# Altium schematic sheet CONNECTORS.SchDoc
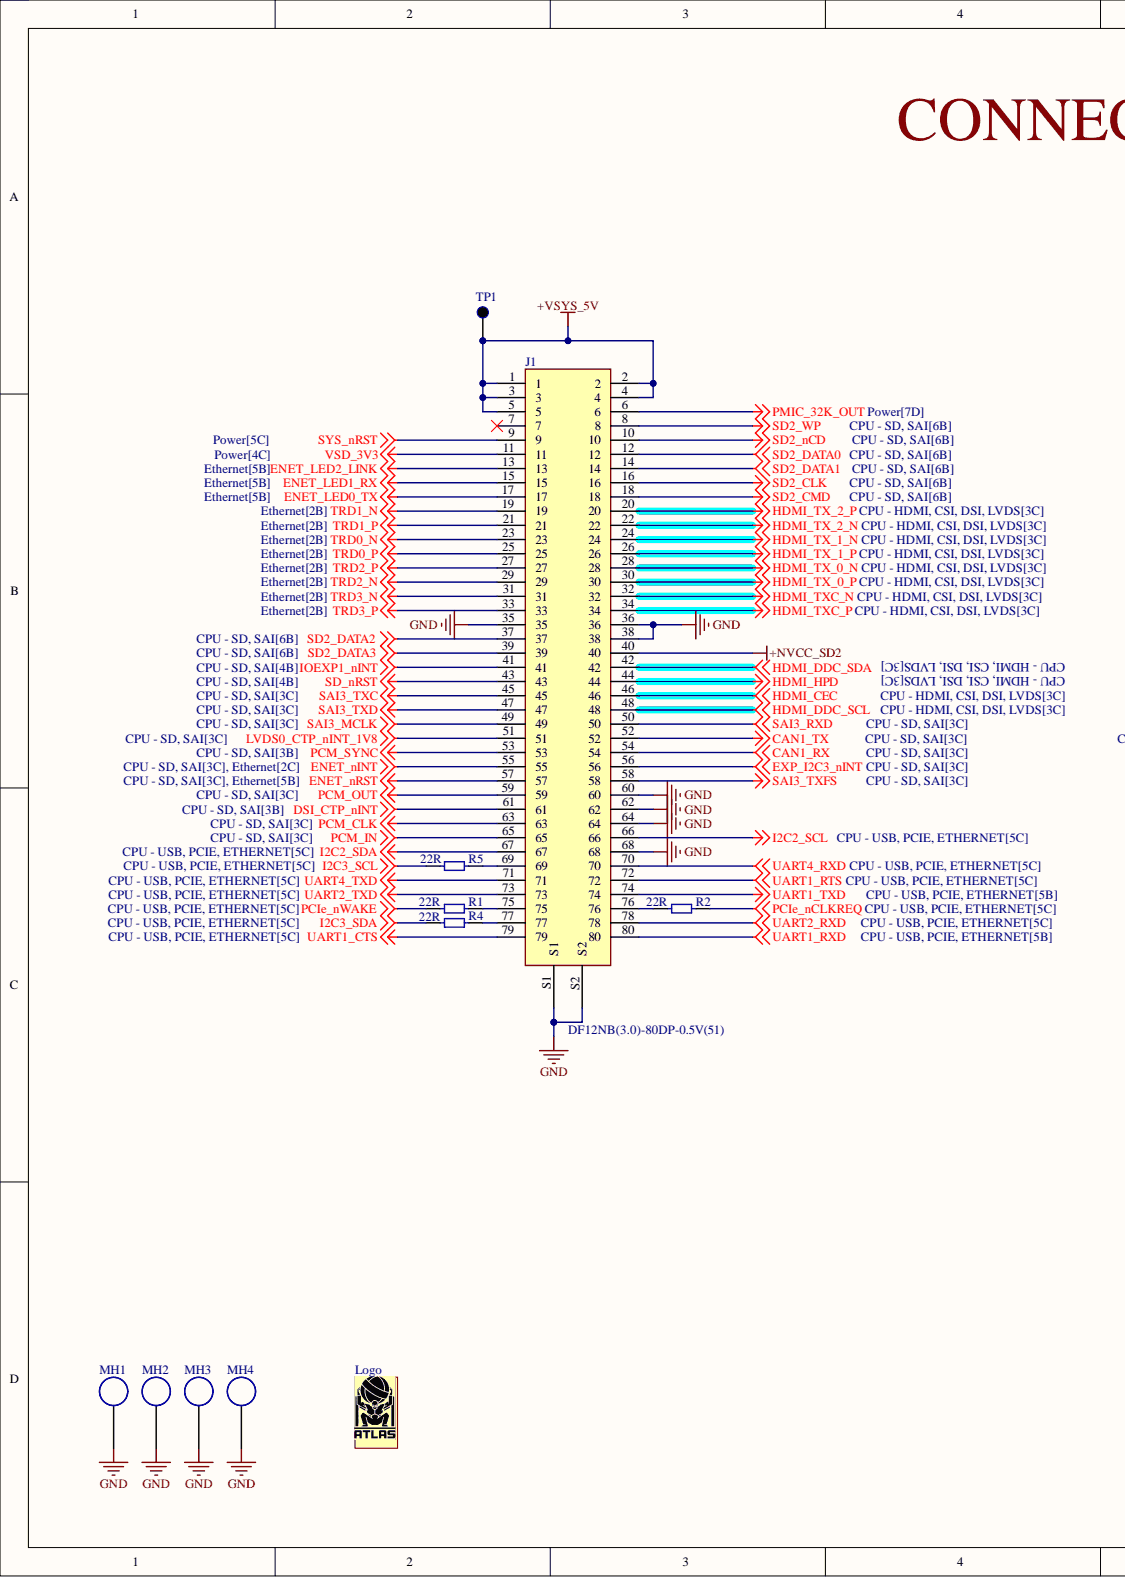
[diagram p0: CONNECTORS.SchDoc, left]
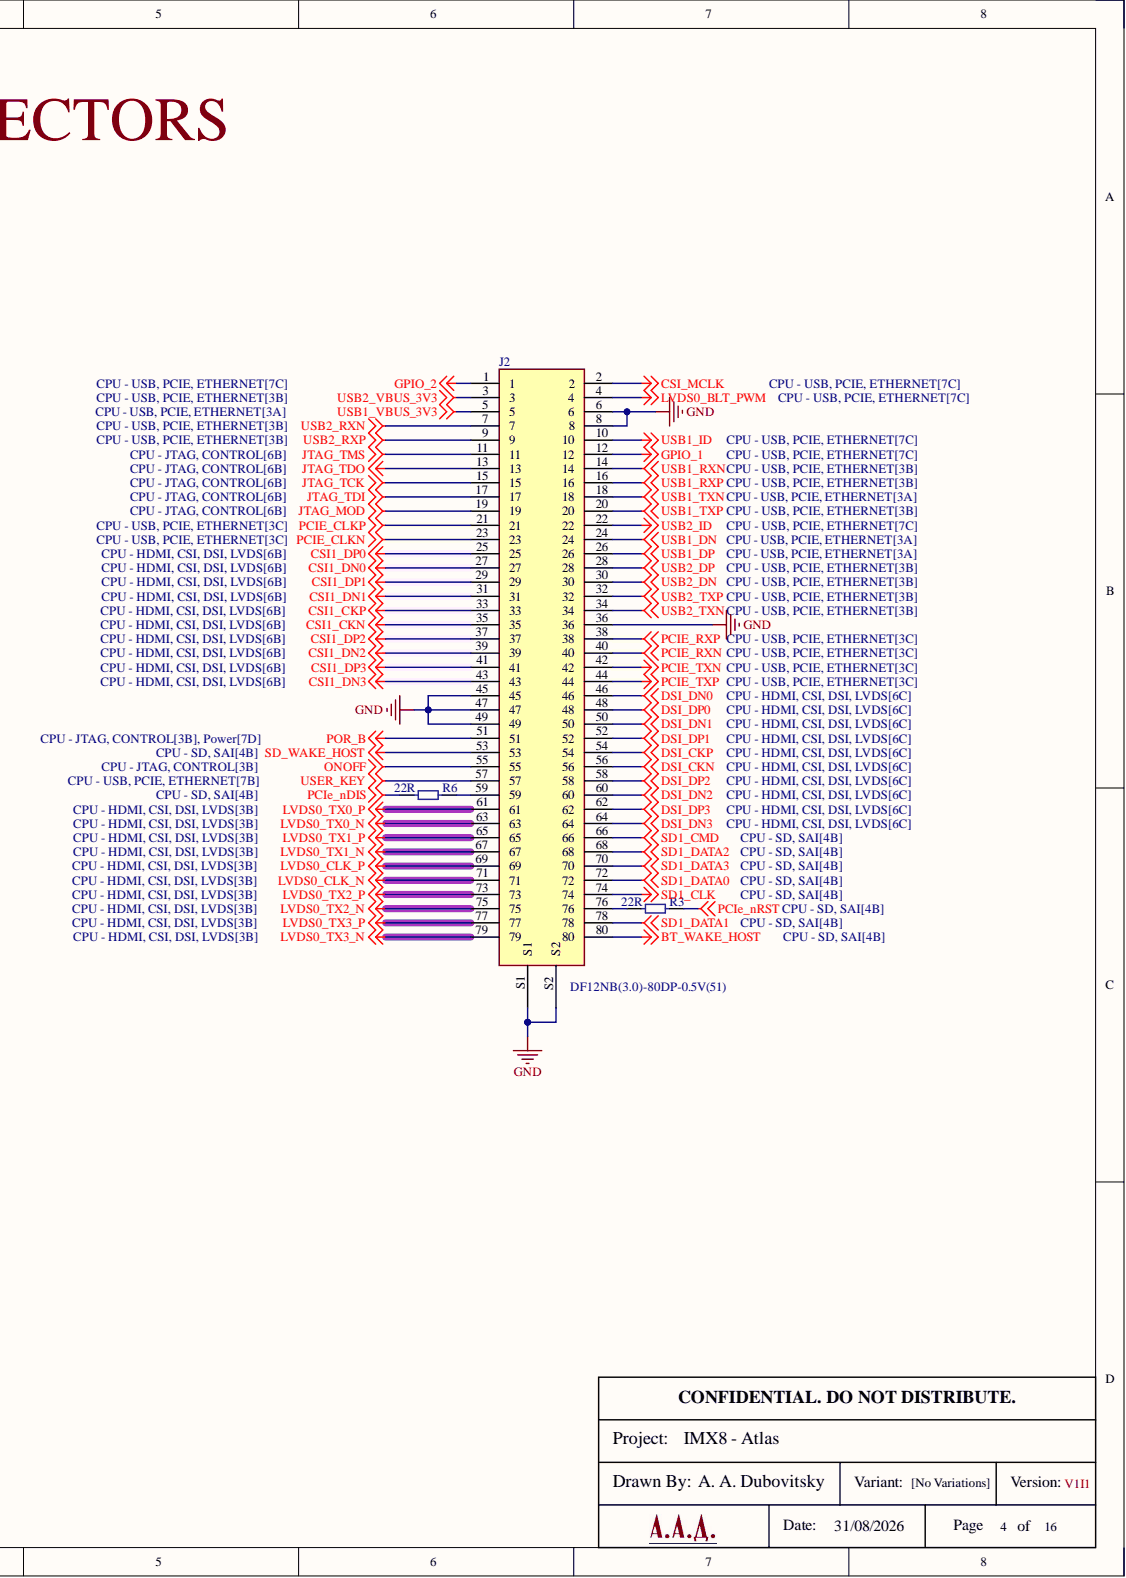
[diagram p1: CONNECTORS.SchDoc, right]

## Parts
J1  DF12NB(3.0)-80DP-0.5V(51)  [HRS_DF12NB(3.0)-80DP-0.5V(51)]
J2  DF12NB(3.0)-80DP-0.5V(51)  [HRS_DF12NB(3.0)-80DP-0.5V(51)]
Logo  Logo  [ATLAS LOGO]
MH1  Mounting Hole  [Mounting Hole]  (value not drawn)
MH2  Mounting Hole  [Mounting Hole]  (value not drawn)
MH3  Mounting Hole  [Mounting Hole]  (value not drawn)
MH4  Mounting Hole  [Mounting Hole]  (value not drawn)
R1  22R  [RES 0402_1005]
R2  22R  [RES 0402_1005]
R3  22R  [RES 0402_1005]
R4  22R  [RES 0402_1005]
R5  22R  [RES 0402_1005]
R6  22R  [RES 0402_1005]
TP1  Test Point  [Test Point Pad]  (value not drawn)

## Nets
+NVCC_SD2: J1.40
+VSYS_5V: J1.1 J1.2 J1.3 J1.4 J1.5 TP1.1
BT_WAKE_HOST: J2.80
CAN1_RX: J1.54
CAN1_TX: J1.52
CSI1_CKN: J2.35
CSI1_CKP: J2.33
CSI1_DN0: J2.27
CSI1_DN1: J2.31
CSI1_DN2: J2.39
CSI1_DN3: J2.43
CSI1_DP0: J2.25
CSI1_DP1: J2.29
CSI1_DP2: J2.37
CSI1_DP3: J2.41
CSI_MCLK: J2.2
DSI_CKN: J2.56
DSI_CKP: J2.54
DSI_CTP_nINT: J1.61
DSI_DN0: J2.46
DSI_DN1: J2.50
DSI_DN2: J2.60
DSI_DN3: J2.64
DSI_DP0: J2.48
DSI_DP1: J2.52
DSI_DP2: J2.58
DSI_DP3: J2.62
ENET_LED0_TX: J1.17
ENET_LED1_RX: J1.15
ENET_LED2_LINK: J1.13
ENET_nINT: J1.55
ENET_nRST: J1.57
EXP_I2C3_nINT: J1.56
GND: J1.35 J1.36 J1.38 J1.60 J1.62 J1.64 J1.68 J1.S1 J1.S2 J2.6 J2.8 J2.36 J2.45 J2.47 J2.49 J2.S1 J2.S2 MH1.1 MH2.1 MH3.1 MH4.1
GPIO_1: J2.12
GPIO_2: J2.1
HDMI_CEC: J1.46
HDMI_DDC_SCL: J1.48
HDMI_DDC_SDA: J1.42
HDMI_HPD: J1.44
HDMI_TX_0_N: J1.28
HDMI_TX_0_P: J1.30
HDMI_TX_1_N: J1.24
HDMI_TX_1_P: J1.26
HDMI_TX_2_N: J1.22
HDMI_TX_2_P: J1.20
HDMI_TXC_N: J1.32
HDMI_TXC_P: J1.34
I2C2_SCL: J1.66
I2C2_SDA: J1.67
I2C3_SCL: R5.1
I2C3_SDA: R4.1
IOEXP1_nINT: J1.41
JTAG_MOD: J2.19
JTAG_TCK: J2.15
JTAG_TDI: J2.17
JTAG_TDO: J2.13
JTAG_TMS: J2.11
LVDS0_BLT_PWM: J2.4
LVDS0_CLK_N: J2.71
LVDS0_CLK_P: J2.69
LVDS0_CTP_nINT_1V8: J1.51
LVDS0_TX0_N: J2.63
LVDS0_TX0_P: J2.61
LVDS0_TX1_N: J2.67
LVDS0_TX1_P: J2.65
LVDS0_TX2_N: J2.75
LVDS0_TX2_P: J2.73
LVDS0_TX3_N: J2.79
LVDS0_TX3_P: J2.77
ONOFF: J2.55
PCIE_CLKN: J2.23
PCIE_CLKP: J2.21
PCIe_nCLKREQ: R2.1
PCIe_nDIS: R6.1
PCIe_nRST: R3.1
PCIe_nWAKE: R1.1
PCIE_RXN: J2.40
PCIE_RXP: J2.38
PCIE_TXN: J2.42
PCIE_TXP: J2.44
PCM_CLK: J1.63
PCM_IN: J1.65
PCM_OUT: J1.59
PCM_SYNC: J1.53
PMIC_32K_OUT: J1.6
POR_B: J2.51
SAI3_MCLK: J1.49
SAI3_RXD: J1.50
SAI3_TXC: J1.45
SAI3_TXD: J1.47
SAI3_TXFS: J1.58
SD1_CLK: J2.74
SD1_CMD: J2.66
SD1_DATA0: J2.72
SD1_DATA1: J2.78
SD1_DATA2: J2.68
SD1_DATA3: J2.70
SD2_CLK: J1.16
SD2_CMD: J1.18
SD2_DATA0: J1.12
SD2_DATA1: J1.14
SD2_DATA2: J1.37
SD2_DATA3: J1.39
SD2_nCD: J1.10
SD2_WP: J1.8
SD_nRST: J1.43
SD_WAKE_HOST: J2.53
SYS_nRST: J1.9
TRD0_N: J1.23
TRD0_P: J1.25
TRD1_N: J1.19
TRD1_P: J1.21
TRD2_N: J1.29
TRD2_P: J1.27
TRD3_N: J1.31
TRD3_P: J1.33
UART1_CTS: J1.79
UART1_RTS: J1.72
UART1_RXD: J1.80
UART1_TXD: J1.74
UART2_RXD: J1.78
UART2_TXD: J1.73
UART4_RXD: J1.70
UART4_TXD: J1.71
USB1_DN: J2.24
USB1_DP: J2.26
USB1_ID: J2.10
USB1_RXN: J2.14
USB1_RXP: J2.16
USB1_TXN: J2.18
USB1_TXP: J2.20
USB1_VBUS_3V3: J2.5
USB2_DN: J2.30
USB2_DP: J2.28
USB2_ID: J2.22
USB2_RXN: J2.7
USB2_RXP: J2.9
USB2_TXN: J2.34
USB2_TXP: J2.32
USB2_VBUS_3V3: J2.3
USER_KEY: J2.57
VSD_3V3: J1.11
NetJ1_69: J1.69 R5.2
NetJ1_75: J1.75 R1.2
NetJ1_76: J1.76 R2.2
NetJ1_77: J1.77 R4.2
NetJ2_59: J2.59 R6.2
NetJ2_76: J2.76 R3.2

Not connected: J1 7

## Library data of the parts
J1 J2 = DF12NB(3.0)-80DP-0.5V(51): 80 Position Connector Header, Outer Shroud Contacts Surface Mount Gold; MF: Hirose Electric Co Ltd; Check_prices: https://www.snapeda.com/parts/DF12NB(3.0)-80DP-0.5V(51)/Hirose/view-part/?ref=eda; Component Kind: Standard; MP: DF12NB(3.0)-80DP-0.5V(51); Package: None; SnapEDA_Link: https://www.snapeda.com/parts/DF12NB(3.0)-80DP-0.5V(51)/Hirose/view-part/?ref=snap; Library Name: Atlas - Symbols.SchLib
Logo = ATLAS Logo: Atlas PCB Logo; Component Kind: Standard; Library Name: Atlas - Symbols.SchLib
R1-R6 = 0402WGF220JTCE: Res. 22 Ω, 62.5mW, ±1%; .PCB_Checked: A.A.D.; Component Kind: Standard; .Created: A.A.D.; .SCH_Checked: A.A.D.; Library Name: Atlas - Symbols.SchLib
MH1-MH4 = Mounting Hole: Component Kind: Standard; Library Name: Atlas - Symbols.SchLib
TP1 = Test Point: Component Kind: Standard; Library Name: Atlas - Symbols.SchLib
